# Altium schematic sheet Battery_Pack_1A.SchDoc(Battery_Pack_1A)
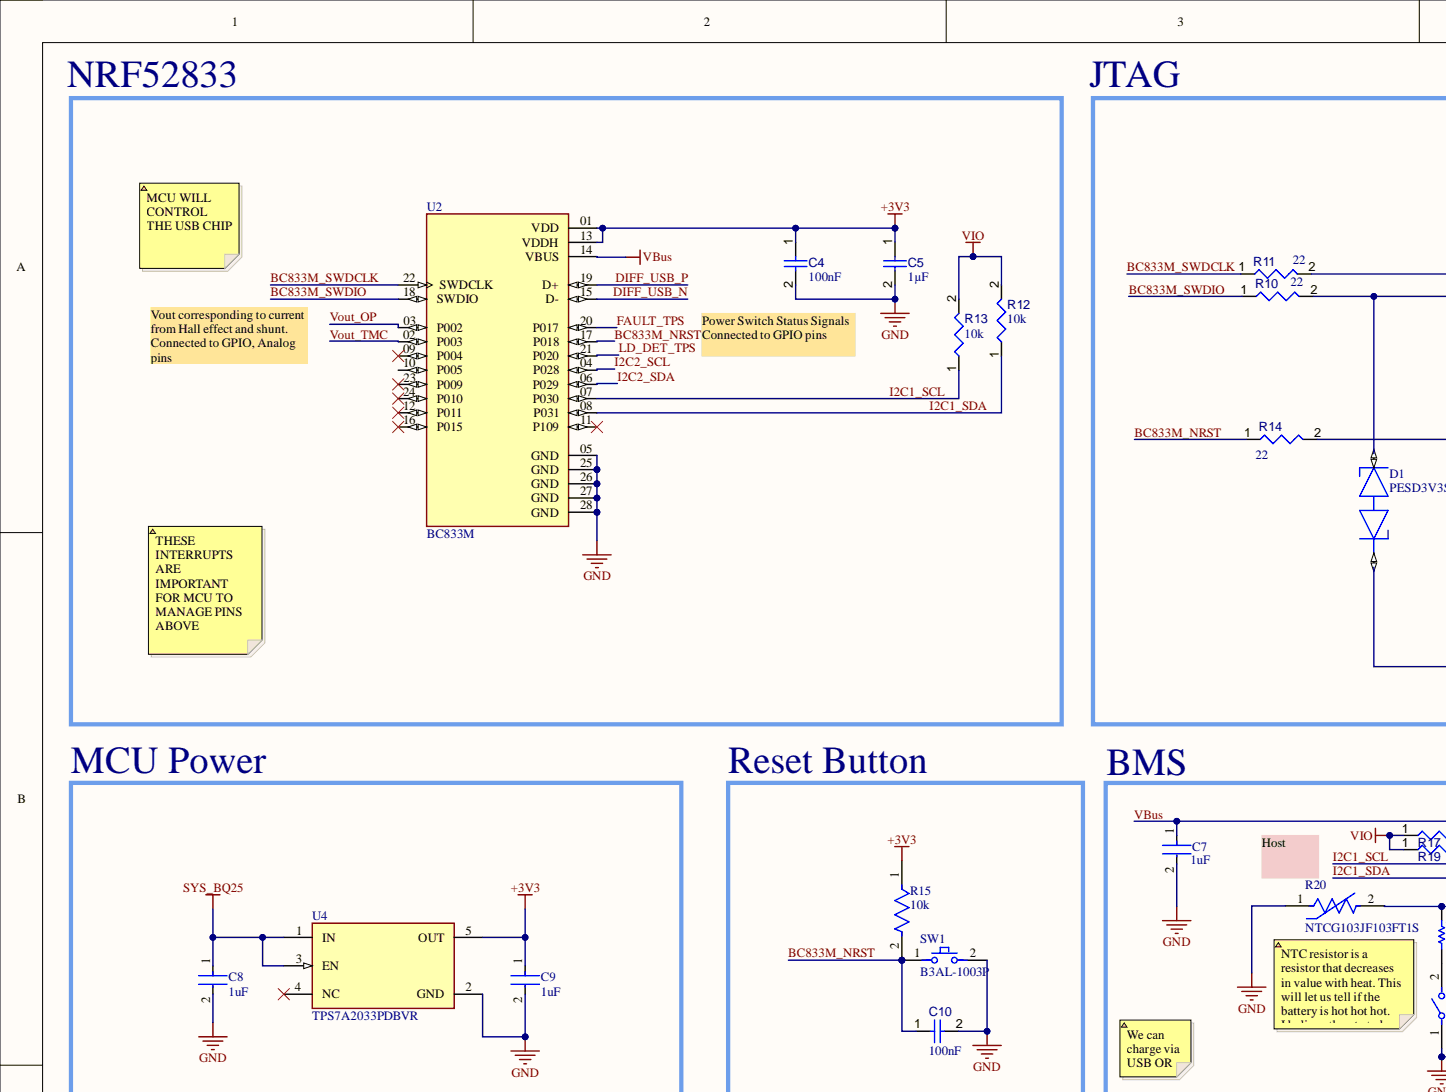
[diagram p0: Battery_Pack_1A.SchDoc, top left]
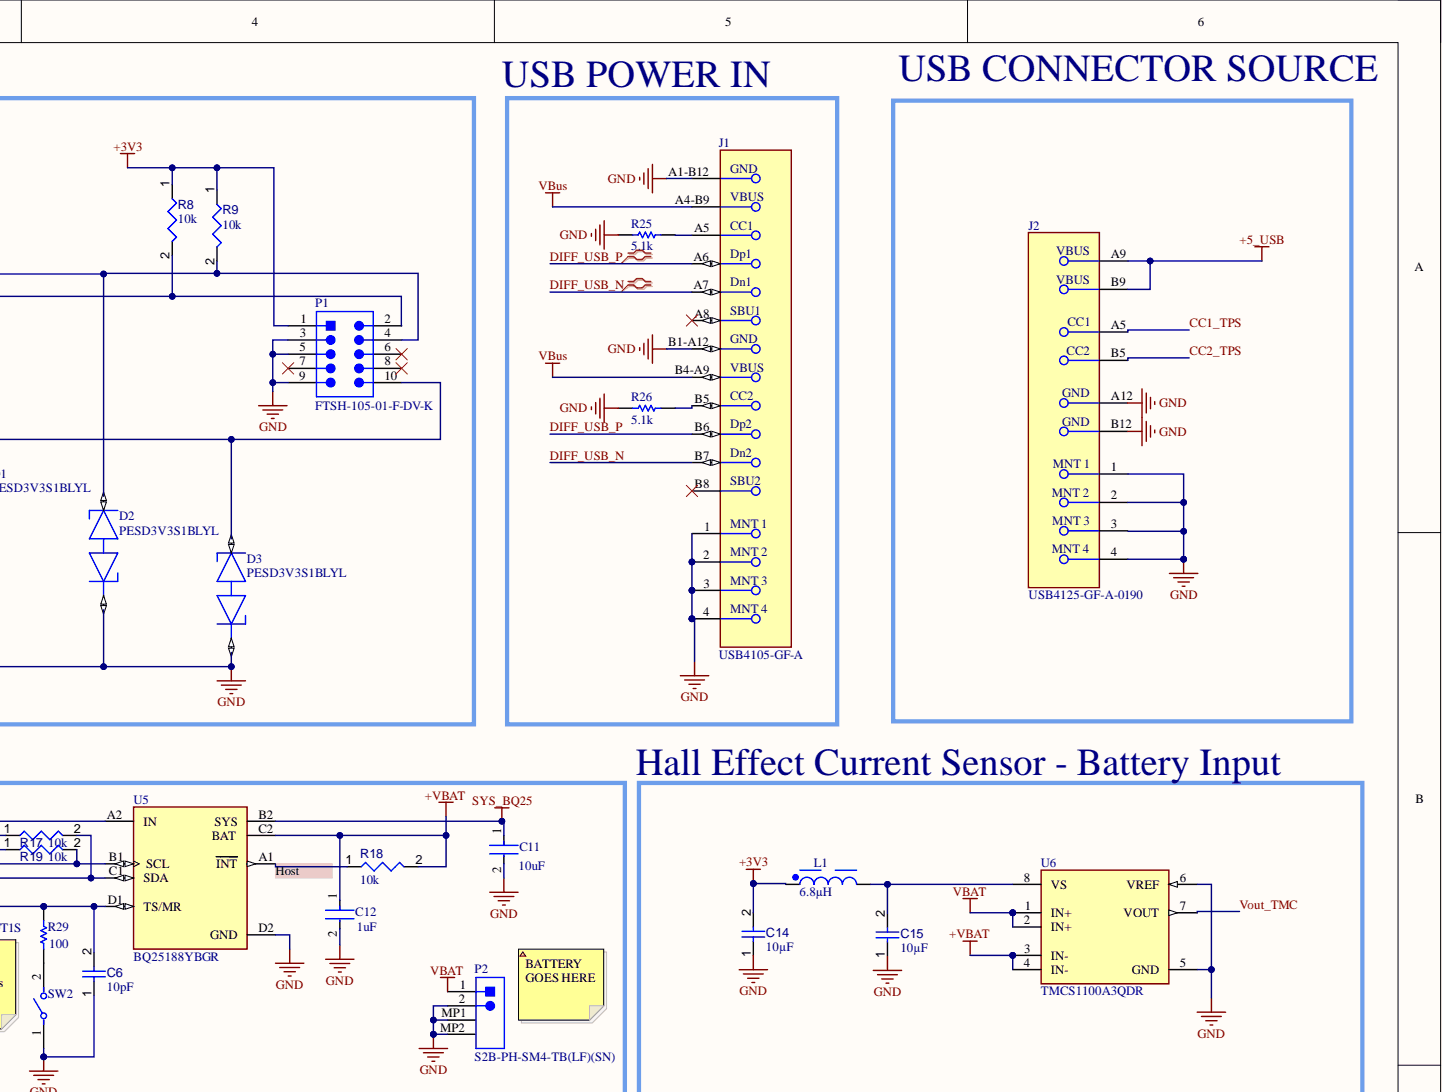
[diagram p1: Battery_Pack_1A.SchDoc, top right]
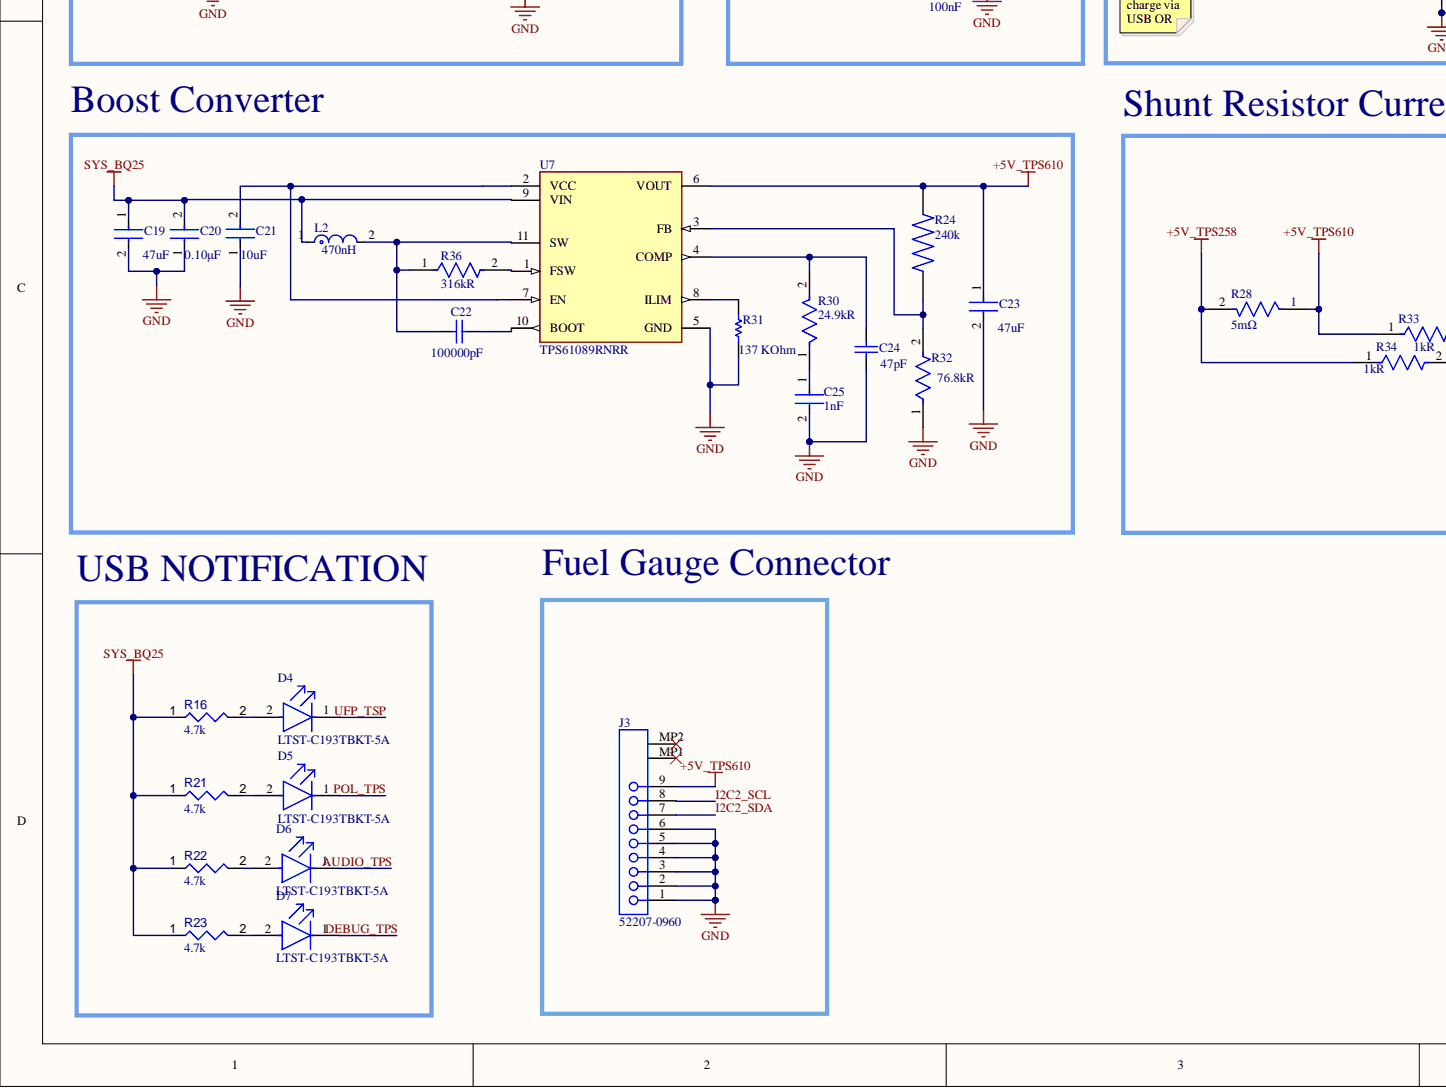
[diagram p2: Battery_Pack_1A.SchDoc, bottom left]
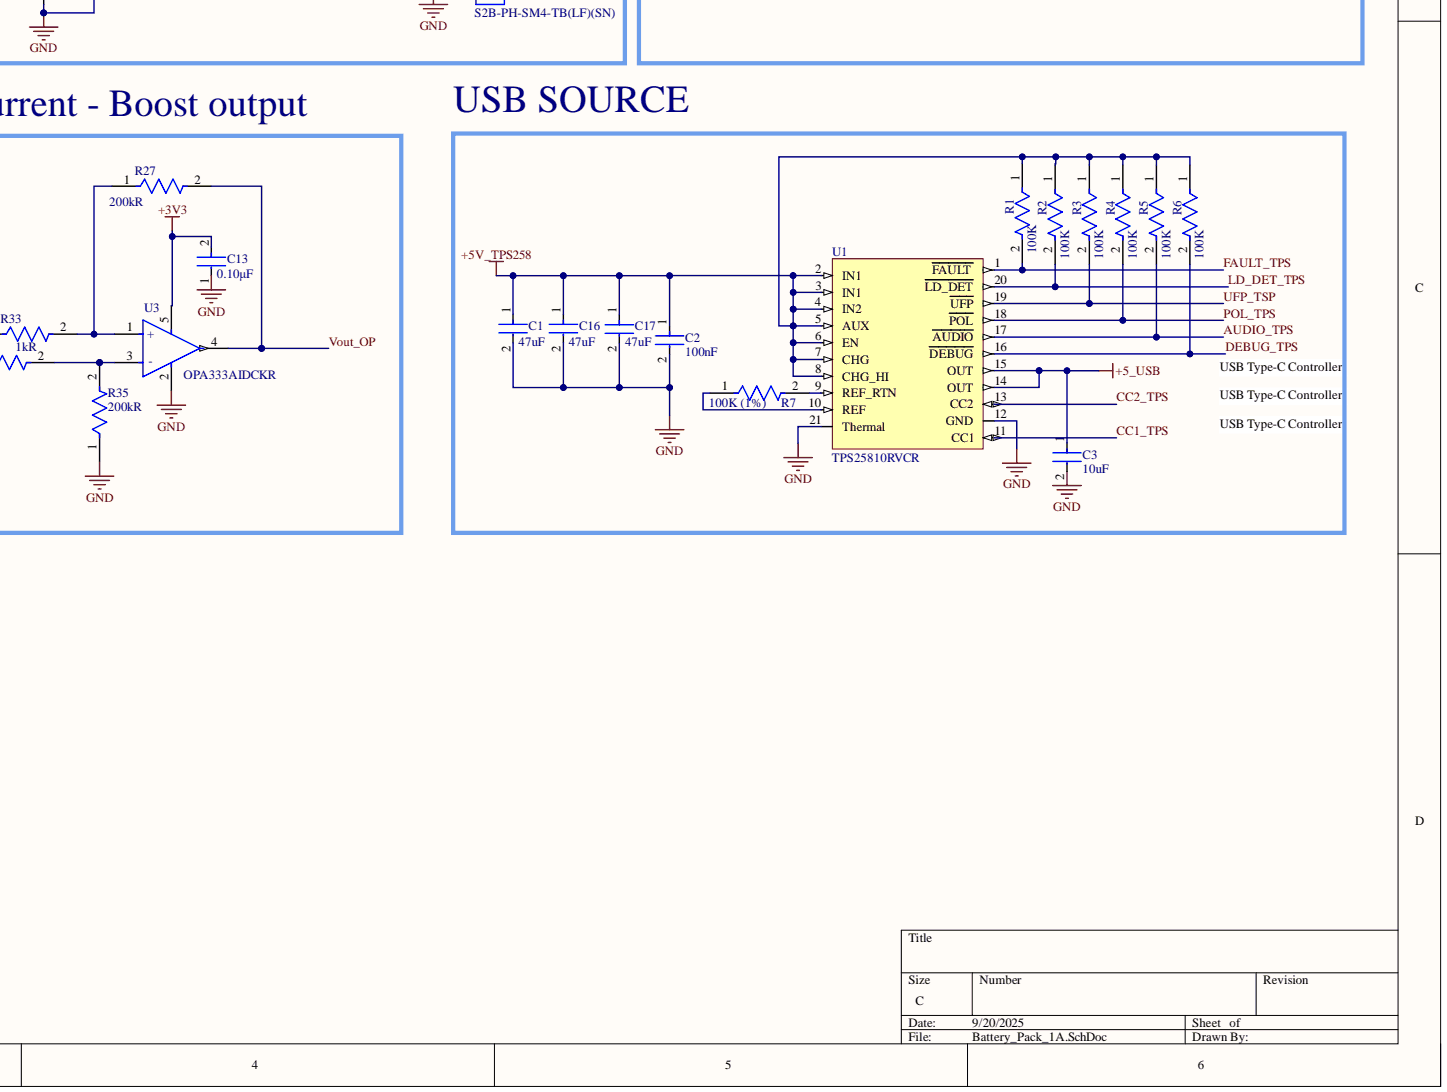
[diagram p3: Battery_Pack_1A.SchDoc, bottom right]

## Parts
C1  Capacitance: 47uF  (hidden comment: GRM21BR61A476ME15K)
C2  value 100nF  (hidden comment: CL05B104KA5NNNC)
C3  10uF
C4  value 100nF  (hidden comment: Capacitor 100nF +/-20% 25V 0402)
C5  value 1µF  (hidden comment: Capacitor 1uF +/- 10% 16V 0603)
C6  value 10pF  (hidden comment: Capacitor 10 pF +/- 5% 50 V 0402)
C7  Capacitance: 1uF  (hidden comment: EMK105BJ105KV-F)
C8  1uF
C9  1uF
C10  value 100nF  (hidden comment: Capacitor 100nF +/-20% 25V 0402)
C11  Capacitance: 10uF  (hidden comment: GRM155R61A106ME11D)
C12  Capacitance: 1uF  (hidden comment: EMK105BJ105KV-F)
C13  value 0.10μF  Capacitance: 0.10μF  (hidden comment: GRM155R71C104KA88D)
C14  value 10µF  (hidden comment: Capacitor 10µF +/-20% 25V 0805)
C15  value 10µF  (hidden comment: Capacitor 10µF +/-20% 25V 0805)
C16  Capacitance: 47uF  (hidden comment: GRM21BR61A476ME15K)
C17  Capacitance: 47uF  (hidden comment: GRM21BR61A476ME15K)
C19  Capacitance: 47uF  (hidden comment: GRM32ER61C476KE15L)
C20  value 0.10μF  Capacitance: 0.10μF  (hidden comment: GRM155R71C104KA88D)
C21  Capacitance: 10uF  (hidden comment: C1608X5R1A106M080AC)
C22  Capacitance: 100000pF  (hidden comment: GRM155R71A104KA01D)
C23  Capacitance: 47uF  (hidden comment: GRM32ER61C476KE15L)
C24  Capacitance: 47pF  (hidden comment: GRM1555C1H470GA01D)
C25  Capacitance: 1nF  (hidden comment: GRM1555C1H102JA01J)
D1  PESD3V3S1BLYL
D2  PESD3V3S1BLYL
D3  PESD3V3S1BLYL
D4  LTST-C193TBKT-5A
D5  LTST-C193TBKT-5A
D6  LTST-C193TBKT-5A
D7  LTST-C193TBKT-5A
J1  USB4105-GF-A
J2  USB4125-GF-A-0190
J3  52207-0960
L1  Inductance: 6.8µH  (hidden comment: 74439346068)
L2  Inductance: 470nH  (hidden comment: XFL4015-471MEB)
P1  FTSH-105-01-F-DV-K
P2  S2B-PH-SM4-TB(LF)(SN)
R1  100K
R2  100K
R3  100K
R4  100K
R5  100K
R6  100K
R7  100K (1%)
R8  value 10k  (hidden comment: 10KR2F)
R9  value 10k  (hidden comment: 10KR2F)
R10  value 22  (hidden comment: 22R2J)
R11  value 22  (hidden comment: 22R2J)
R12  value 10k  (hidden comment: Resistor 10k +/-1% 0402 100 mW)
R13  value 10k  (hidden comment: Resistor 10k +/-1% 0402 100 mW)
R14  value 22  (hidden comment: 22R2J)
R15  10k
R16  value 4.7k  (hidden comment: Resistor 4k7 +/-1% 0603 100 mW)
R17  value 10k  (hidden comment: Resistor 10k +/-1% 0402 100 mW)
R18  value 10k  (hidden comment: Resistor 10k +/-1% 0603 100 mW)
R19  value 10k  (hidden comment: Resistor 10k +/-1% 0402 100 mW)
R20  NTCG103JF103FT1S
R21  value 4.7k  (hidden comment: Resistor 4k7 +/-1% 0603 100 mW)
R22  value 4.7k  (hidden comment: Resistor 4k7 +/-1% 0603 100 mW)
R23  value 4.7k  (hidden comment: Resistor 4k7 +/-1% 0603 100 mW)
R24  value 240k  (hidden comment: RC0603FR-07240KL)
R25  Resistance: 5.1k  (hidden comment: RC0603FR-075K1L)
R26  Resistance: 5.1k  (hidden comment: RC0603FR-075K1L)
R27  Resistance: 200kR  (hidden comment: CRCW0603200KFKEA)
R28  value 5mΩ  Resistance: 5mΩ  (hidden comment: PU2512FKM130R005L)
R29  RC0402FR-07100RL  (value not drawn)
R30  Resistance: 24.9kR  (hidden comment: CRCW040224K9FKED)
R31  CRCW0402137KFKED  (value not drawn)
R32  Resistance: 76.8kR  (hidden comment: CRCW040276K8FKED)
R33  Resistance: 1kR  (hidden comment: AC0603FR-071KL)
R34  Resistance: 1kR  (hidden comment: AC0603FR-071KL)
R35  Resistance: 200kR  (hidden comment: CRCW0603200KFKEA)
R36  Resistance: 316kR  (hidden comment: CRCW0402316KFKED)
SW1  B3AL-1003P
SW2  MDSM-4R-12-18  (value not drawn)
U1  TPS25810RVCR
U2  BC833M
U3  OPA333AIDCKR
U4  TPS7A2033PDBVR
U5  BQ25188YBGR
U6  TMCS1100A3QDR
U7  TPS61089RNRR

## Nets
+3V3: C4.1 C5.1 C9.1 C13.2 C14.2 L1.1 P1.1 R8.1 R9.1 R15.1 U2.01(VDD) U2.13(VDDH) U3.5 U4.5(OUT)
+5_USB: C3.1 J2.A9 J2.B9 U1.14(OUT) U1.15(OUT)
+5V_TPS258: C1.1 C2.1 C16.1 C17.1 R1.1 R2.1 R3.1 R4.1 R5.1 R6.1 R28.2 R34.1 U1.2(IN1) U1.3(IN1) U1.4(IN2) U1.5(AUX) U1.6(EN) U1.7(CHG) U1.8(CHG_HI)
+5V_TPS610: C23.1 J3.9 R24.2 R28.1 R33.1 U7.6(VOUT)
+VBAT: C12.1 R18.2 U5.C2(BAT) U6.3(IN-) U6.4(IN-)
GND: C1.2 C2.2 C3.2 C4.2 C5.2 C6.1 C7.2 C8.2 C9.2 C10.2 C11.2 C12.2 C13.1 C14.1 C15.1 C16.2 C17.2 C19.2 C20.1 C21.1 C23.2 C24.1 C25.2 D1.1 D2.1 D3.1 J1.1 J1.2 J1.3 J1.4 J1.A1-B12 J1.B1-A12 J2.1 J2.2 J2.4 J2.A12 J2.B12 J3.1 J3.2 J3.3 J3.4 J3.5 J3.6 P1.3 P1.5 P1.9 P2.2 P2.MP1 P2.MP2 R20.1 R25.1 R26.1 R31.1 R32.1 R35.1 SW1.2 SW2.1 U1.12(GND) U1.21(Thermal) U2.05(GND) U2.25(GND) U2.26(GND) U2.27(GND) U2.28(GND) U3.2 U4.2(GND) U5.D2(GND) U6.5(GND) U6.6(VREF) U7.5(GND)
SYS_BQ25: C8.1 C11.1 C19.1 C20.2 L2.1 R16.1 R21.1 R22.1 R23.1 U4.1(IN) U4.3(EN) U5.B2(SYS) U7.9(VIN)
VBAT: P2.1 U6.1(IN+) U6.2(IN+)
VIO: R12.2 R13.2 R17.1 R19.1
AUDIO_TPS: D6.1 R5.2 U1.17(AUDIO)
BC833M_NRST: C10.1 R14.1 R15.2 SW1.1 U2.17(P018)
BC833M_SWDCLK: R11.1 U2.22(SWDCLK)
BC833M_SWDIO: R10.1 U2.18(SWDIO)
CC1_TPS: J2.A5 U1.11(CC1)
CC2_TPS: J2.B5 U1.13(CC2)
DEBUG_TPS: D7.1 R6.2 U1.16(DEBUG)
DIFF_USB_N: J1.A7 J1.B7 U2.15(D-)
DIFF_USB_P: J1.A6 J1.B6 U2.19(D+)
FAULT_TPS: R1.2 U1.1(FAULT) U2.20(P017)
I2C1_SCL: R13.1 R19.2 U2.07(P030) U5.B1(SCL)
I2C1_SDA: R12.1 R17.2 U2.08(P031) U5.C1(SDA)
I2C2_SCL: J3.8 U2.04(P028)
I2C2_SDA: J3.7 U2.06(P029)
LD_DET_TPS: R2.2 U1.20(LD_DET) U2.21(P020)
POL_TPS: D5.1 R4.2 U1.18(POL)
UFP_TSP: D4.1 R3.2 U1.19(UFP)
VBus: C7.1 J1.A4-B9 J1.B4-A9 U2.14(VBUS) U5.A2(IN)
Vout_OP: R27.2 U2.03(P002) U3.4
Vout_TMC: U2.02(P003) U6.7(VOUT)
NetC6_2: C6.2 R20.2 R29.2 U5.D1(TS/MR)
NetC15_2: C15.2 L1.2 U6.8(VS)
NetC21_2: C21.2 U7.2(VCC) U7.7(EN)
NetC22_1: C22.1 L2.2 R36.1 U7.11(SW)
NetC22_2: C22.2 U7.10(BOOT)
NetC24_2: C24.2 R30.2 U7.4(COMP)
NetC25_1: C25.1 R30.1
NetD1_2: D1.2 P1.2 R8.2 R10.2
NetD2_2: D2.2 P1.4 R9.2 R11.2
NetD3_2: D3.2 P1.10 R14.2
NetD4_2: D4.2 R16.2
NetD5_2: D5.2 R21.2
NetD6_2: D6.2 R22.2
NetD7_2: D7.2 R23.2
NetJ1_A5: J1.A5 R25.2
NetJ1_B5: J1.B5 R26.2
NetR7_1: R7.1 U1.10(REF)
NetR7_2: R7.2 U1.9(REF_RTN)
NetR18_1: R18.1 U5.A1(INT)
NetR24_1: R24.1 R32.2 U7.3(FB)
NetR27_1: R27.1 R33.2 U3.1(+)
NetR29_1: R29.1 SW2.2
NetR31_2: R31.2 U7.8(ILIM)
NetR34_2: R34.2 R35.2 U3.3(-)
NetR36_2: R36.2 U7.1(FSW)

Not connected: J1 A8 B8; J2 3; J3 MP1 MP2; P1 6 7 8; U2 09(P004) 10(P005) 11(P109) 12(P011) 16(P015) 23(P009) 24(P010); U4 4(NC)

## Library data of the parts
C1 C16 C17 = CMP-06035-042633-1: Chip Multilayer Ceramic Capacitors for General Purpose, 0805, 47uF, X5R, 15%, 20%, 10V; Case Code (Imperial): 0805; Case Code (Metric): 2012; Case/Package: 0805; Component Kind: Standard; Dielectric: X5R; Length: 0.079inch; Library Name: Altium Content Vault; Max Operating Temperature: 85°C; Min Operating Temperature: -55°C; Mount: Surface Mount; Package Quantity: 10000; Packaging: Tape and Reel; Termination: SMD/SMT; Thickness: 0.057inch; Tolerance: 20%; Voltage Rating: 10V; Voltage Rating (DC): 10V; Weight: 0.000335oz; Width: 0.049inch
C2 = CMP-001-00119-1: Ceramic Capacitor, Multilayer, Ceramic, 25V, 10% +Tol, 10% -Tol, X7R, 15% TC, 0.1uF, Surface Mount, 0402; Multilayer: Yes; Capacitor Type: Ceramic; Case/Package: 0402; Component Kind: Standard; Dielectric Material: Ceramic; JESD-609 Code: e3; Max Operating Temperature: 125°C; Min Operating Temperature: -55°C; Mounting Technology: Surface Mount; Package Shape: Rectangular; Packaging: Tape and Reel; Pins: 2; Rated DC Voltage (URdc): 25V; Reach SVHC Compliant: Yes; RoHS Compliant: Yes; Temperature Characteristics Code: X7R; Terminal Finish: Nickel; Termination: Wraparound; Tolerance  (fill in as +-): 10%; Tolerance (fill in as +-): 10%; Library Name: SUBC
C3 = CMP-2007-03541-2: Chip Multilayer Ceramic Capacitors for General Purpose, 0805, 10uF, X5R, 15%, 10%, 25V; Capacitance: 10uF; Case Code (Imperial): 0805; Case Code (Metric): 2012; Case/Package: 0805; Component Kind: Standard; Depth: 1.25mm; Dielectric: X5R; Height: 1.25mm; Lead Free: Lead Free; Length: 2mm; Library Name: Altium Content Vault; Material: Ceramic; Max Operating Temperature: 85°C; Min Operating Temperature: -55°C; Mount: Surface Mount; Package Quantity: 1; Packaging: Tape and Reel; Radiation Hardening: No; Termination: SMD/SMT; Thickness: 0.053inch; Tolerance: 10%; Voltage: 25V; Voltage Rating: 25V; Voltage Rating (DC): 25V; Width: 0.049inch
C4 C10 = CMP-001-00065-4: Chip Capacitor, 100nF +/-20%, 25V, 0402, Thickness 0.6 mm; Tolerance: 10%; Case/Package: 0402; Component Kind: Standard; Max Operating Temperature: 85°C; Min Operating Temperature: -55°C; Mounting Technology: SMT; Pins: 2; Voltage Rating: 25V; Library Name: SUBC
C5 = CMP-001-00004-6: Chip Capacitor, 1 uF, +/- 10%, 16 V, 0603 (1608 Metric); Capacitor Type: Ceramic; Case/Package: 0603; Component Kind: Standard; Max Operating Temperature: 125°C; Min Operating Temperature: -55°C; Mounting Technology: SM; Pins: 2; RoHS Compliant: True; Tolerance: 10%; Voltage Rating: 16V; Library Name: SUBC
C6 = CMP-001-00013-6: Chip Capacitor, 10 pF, +/- 5%, 50 V, 0402 (1005 Metric); Capacitor Type: Ceramic; Voltage Rating: 50V; Case/Package: 0402; Component Kind: Standard; Max Operating Temperature: 125°C; Min Operating Temperature: -55°C; Mounting Technology: SM; Pins: 2; RoHS Compliant: True; Tolerance: 5%; Library Name: SUBC
C7 C12 = CMP-14477-000104-2: None; Dielectric: X5R; Tolerance: 10%; Voltage Rating: 16V; Case Code (Imperial): 0402; Case Code (Metric): 1005; Case/Package: 0402; Component Kind: Standard; Construction: Flat; Depth: 0.5mm; Halogen Free: Halogen Free; Height: 0.5mm; Length: 1mm; Library Name: Altium Content Vault; Max Operating Temperature: 85°C; Min Operating Temperature: -55°C; Mount: Surface Mount; Number of Pins: 2; Package Quantity: 1; Packaging: Tape and Reel; Radiation Hardening: No; RoHS Compliant: Yes; Series: M; Termination: SMD/SMT; Thickness: 0.022inch; Voltage Rating (DC): 16V; Width: 0.02inch
C8 C9 = CMP-2000-05016-2: General Purpose Ceramic Capacitor, 0805, 100nF, 10%, X7R, 0.15, 50V; Dissipation Factor: 2.5%; Insulation Resistance: 100GR; Capacitance: 100nF; Case Code (Imperial): 0805; Case Code (Metric): 2012; Case/Package: 0805; Component Kind: Standard; Depth: 1.25mm; Dielectric: X7R; Dielectric Material: Ceramic; Height: 0.5mm; Lead Free: Lead Free; Length: 2mm; Library Name: Altium Content Vault; Max Operating Temperature: 125°C; Min Operating Temperature: -55°C; Mount: Surface Mount; Number of Pins: 2; Package Quantity: 4000; Packaging: Cut Tape; Radiation Hardening: No; REACH SVHC: No SVHC; RoHS Compliant: Yes; Series: MLCC X7R; Termination: Standard; Thickness: 0.037inch; Tolerance: 10%; Voltage: 50V; Voltage Rating: 50V; Voltage Rating (DC): 50V; Weight: 0.001411oz; Width: 1.2mm
C11 = CMP-06035-026070-1: Chip Multilayer Ceramic Capacitors for General Purpose, 0402, 10uF, X5R, 15%, 20%, 10V; Component Kind: Standard; Library Name: Altium Content Vault
C13 C20 = CMP-2100-03641-2: CAP CER 0.1UF 16V X7R 0402; Manufacturer: Murata; Material: Ceramic; Standoff Height: 0mm; Tolerance: 10%; Voltage Rating: 16Vdc; Category: Cap; Component Kind: Standard; ComponentLink2Description: Datasheet; ComponentLink2URL: https://search.murata.co.jp/Ceramy/image/img/A01X/G101/ENG/GRM155R71H471KA01-01A.pdf; Device Class L1: Passive Components; Device Class L2: Capacitors; Device Class L3: Ceramic Capacitors; Digikey Description: CAP CER 0.1UF 16V X7R 0402; Height: 0.55mm; Ipc Land Pattern Name: CAPC10050X219; Lead Free: yes; Library Name: Altium Content Vault; Manufacturer Part Number: GRM155R71C104KA88D; Mouser Description: 0402 0.1 uF 16V ±10 % Tolerance X7R SMT Multilayer Ceramic Capacitor; Octopart: https://octopart.com/grm155r71c104ka88d-murata-196880; Package: GRM15; RoHS: TRUE; Temperature: -55°C to +125°C; Temperature Characteristic: X7R; Temperature Range High: +125°C; Temperature Range Low: -55°C
C14 C15 = CMP-001-00079-4: Chip Capacitor, 10µF +/-20%, 25V, 0805, Thickness 1.45 mm; Tolerance: 10%; Case/Package: 0805; Component Kind: Standard; Max Operating Temperature: 85°C; Min Operating Temperature: -55°C; Mounting Technology: SMT; Pins: 2; Voltage Rating: 25V; Library Name: SUBC
C19 C23 = CMP-2000-06362-2: CAP CER 47UF 16V X5R 1210; Material: Ceramic; Tolerance: 10%; Case Code (Imperial): 1210; Case Code (Metric): 3225; Case/Package: 1210; Component Kind: Standard; Depth: 2.5mm; Dielectric: X5R; Height: 2.5mm; Lead Free: Lead Free; Length: 3.2mm; Library Name: Altium Content Vault; Max Operating Temperature: 85°C; Min Operating Temperature: -55°C; Mount: Surface Mount; Number of Pins: 2; Package Quantity: 1; Packaging: Cut Tape; Radiation Hardening: No; REACH SVHC: No SVHC; RoHS Compliant: Yes; Termination: SMD/SMT; Thickness: 0.106inch; Voltage: 16V; Voltage Rating: 16V; Voltage Rating (DC): 16V; Width: 0.098inch
C21 = CMP-2000-04980-2: Multilayer Ceramic Capacitors 10μF ±20% 10V X5R SMD 0603; Case Code (Imperial): 0603; Case Code (Metric): 1608; Case/Package: 0603; Component Kind: Standard; Construction: Flat; Depth: 0.8mm; Dielectric: X5R; Dielectric Material: Ceramic; Height: 0.8mm; Lead Free: Lead Free; Length: 1.6mm; Library Name: Altium Content Vault; Max Operating Temperature: 85°C; Min Operating Temperature: -55°C; Mount: Surface Mount; Number of Pins: 2; Package Quantity: 1; Packaging: Tape and Reel; Radiation Hardening: No; REACH SVHC: No SVHC; Resistor Type: Ceramic; RoHS Compliant: Yes; Series: C; Termination: SMD/SMT; Thickness: 0.035inch; Tolerance: 20%; Voltage Rating: 10V; Voltage Rating (DC): 10V; Weight: 7.1E-05oz; Width: 0.031inch
C22 = CMP-2000-06331-1: Chip Capacitor, 0.1 uF, +/- 10%, 10 V, -55 to 125 degC, 0402 (1005 Metric), RoHS, Tape and Reel; Manufacturer: Murata; Dielectric: X7R; Rated Voltage: 10V; Case Size/Dimension: 0402; Component Kind: Standard; Datasheet URL: http://search.murata.co.jp/Ceramy/image/img/A01X/G101/ENG/GRM155R71A104KA01-01.pdf; Datasheet Version: 02/2016; Library Name: Altium Content Vault; Manufacturer URL: http://www.murata.com/; Mounting Technology: SurfaceMount; Package Description: 2-Pin Surface Mount Device, Body 1 x 0.5 mm; Package Reference: 0402; Tolerance (%): ±10%
C24 = CMP-2008-04366-1: GRM1555C1H470GA01D  CAP CER 47PF 50V C0G/NP0 0402; Manufacturer: Murata; Component Kind: Standard; Library Name: Altium Content Vault; Part Number: GRM1555C1H470GA01D
C25 = CMP-2008-04255-2: Chip Multilayer Ceramic Capacitors for General Purpose, 0402, 1000pF, C0G, 30ppm/°C, 5%, 50V; Case Code (Imperial): 0402; Case Code (Metric): 1005; Case/Package: 0402; Component Kind: Standard; Depth: 0.5mm; Dielectric: C0G; Height: 0.5mm; Lead Free: Lead Free; Length: 1mm; Library Name: Altium Content Vault; Max Operating Temperature: 125°C; Min Operating Temperature: -55°C; Mount: Surface Mount; Package Quantity: 50000; Packaging: Tape and Reel; Termination: SMD/SMT; Thickness: 0.022inch; Tolerance: 5%; Voltage: 50V; Voltage Rating: 50V; Voltage Rating (DC): 50V; Width: 0.02inch
D1-D3 = CMP-004-00035-1: 6.5V (Typ) Clamp 15A (8/20µs) Ipp Tvs Diode Surface Mount DFN1006-2; MF: Nexperia; Case/Package: DFN1006-2-2 Nexperia USA Inc.; Check_prices: https://www.snapeda.com/parts/PESD3V3S1BLYL/Nexperia/view-part/?ref=eda; Component Kind: Standard; Component Type: Standard; Design Item ID: PESD3V3S1BLYL; MP: PESD3V3S1BLYL; Pins: 2; SnapEDA_Link: https://www.snapeda.com/parts/PESD3V3S1BLYL/Nexperia/view-part/?ref=snap; Source: PESD3V3S1BLYL.SchLib; Library Name: SUBC
D4-D7 = CMP-007-00044-1: Single Color LED, Blue, Water Clear, 1.15mm; Channels: 1; Forward Current: 20mA; Luminous Intensity-Nom: 15mcd; Overall Height: 0.35mm; Shape: Rectangular; Viewing Angle: 130°; Color: Blue; Component Kind: Standard; JESD-609 Code: e3; Lens Style: WATER CLEAR; Max Operating Temperature: 80°C; Min Operating Temperature: -20°C; Mounting Technology: Surface Mount; Optoelectronic Device Type: SINGLE COLOR LED; Packaging: Tape and Reel; Pins: 2; Reach SVHC Compliant: Yes; RoHS Compliant: Yes; Size: 1.15mm; Terminal Finish: Tin; Terminal Pitch: 1.5mm; Library Name: SUBC
J1 = CMP-002-00130-1: Conn USB 2.0 Type C RCP 24 POS 0.3mm/0.5mm Solder RA SMD 24 Terminal 1 Port T/R; Component Kind: Standard; Library Name: SUBC
J2 = CMP-245163-000004-1: CONN RCPT TYPE C 6P SMD RA; Component Kind: Standard; Library Name: Altium Content Vault
J3 = CMP-1241-00181-1: 1.0 mm Pitch Easy-On(TM) Type FPC Connector, 2.7 mm Mated Height, Right Angle, ZIF, SMT, Top Contact, 9 Circuits, -30 to 85 degC, ELV and RoHS Compliant, Tape and Reel; Manufacturer: Molex; Circuits (Loaded): 9; Current (Max per Contact): 1A; Durability (mating cycles max): 30; Entry Angle: 90degrees Angle; Mated Height: 2.70mm; Material - Metal: Phosphor Bronze; Material - Plating Mating: Gold; Material - Plating Termination: Tin; PCB Locator: No; PCB Retention: Yes; Pitch - Mating Interface: 1.00mm; Voltage (Max): 125V; Component Kind: Standard; ComponentLink1Description: Manufacturer URL; ComponentLink1URL: http://www.molex.com/molex/index.jsp; ComponentLink2Description: Datasheet; ComponentLink2URL: http://www.molex.com/webdocs/datasheets/pdf/en-us/0522070960_FFC_FPC_CONNECTORS.pdf; ComponentLink3Description: Package Specification; ComponentLink3URL: http://www.molex.com/pdm_docs/sd/522070960_sd.pdf; Contact Position: Top; Library Name: Altium Content Vault; Mounting Technology: Surface Mount; Number of Rows: 1; Orientation: Right Angle; PackageDescription: 9-Lead FPC Connector, Pitch 1.0 mm; PackageReference: 52207-0960; PackageVersion: Rev. F, 03/2007; Packing: Tape and Reel; PartNumber: 52207-0960; Pitch - Termination Interface: 1.00mm; Polarized to PCB: Yes; RoHS: TRUE; Stackable: No
L1 = CMP-03913-75811202-1: 74439346068 Fixed Ind 6.8UH 6.5A 17.6MOHM Sm; DC Resistance (DCR): 17.6mΩ; Inductance Tolerance: 20%; Saturation Current: 5.65A; Ambient Temperature Range High: 85°C; Ambient Temperature Range Low: -40°C; Component Kind: Standard; Current Rating: 6.5A; Height: 6.1mm; Length: 6.65mm; Library Name: Altium Content Vault; Max Operating Temperature: 125°C; Min Operating Temperature: -40°C; Mount: Surface Mount; NexarPartId: 75811202; Number of Pins: 2; REACH SVHC: No SVHC; RMS Current (Irms): 6.5A; RoHS: Compliant; Width: 6.45mm
L2 = CMP-11268-000114-1: Surface Mount Power Inductor, XFL4015 Series, 470 nH, 20%, Shielded, 8360 ohm, 11.2 A; Core Material: Composite; DC Resistance (DCR): 8.36mR; Max DC Current: 11.2A; Saturation Current: 3.5A; Self Resonant Frequency: 89MHz; Test Frequency: 100kHz; Case/Package: SMD/SMT; Component Kind: Standard; Height: 1.6mm; Inductance Tolerance: 20%; Lead Free: Contains Lead; Length: 4.3mm; Library Name: Altium Content Vault; Max Operating Temperature: 125°C; Min Operating Temperature: -40°C; Mount: Surface Mount; Package Quantity: 40; Packaging: Tape and Reel; REACH SVHC: No SVHC; RMS Current (Irms): 11.2A; RoHS Compliant: Yes; Series: XFL4015; Shielding: Shielded; Termination: SMD/SMT; Tolerance: 20%; Width: 4.3mm
P1 = CMP-002-00122-1: Board Connector, 10 Contact(s), 2 Row(s), Male, Straight, 0.05 inch Pitch, Surface Mount Terminal, Locking, Black Insulator; Additional Feature: ROHS COMPLIANT; Body Breadth: 0.135inch; Body Length: 0.25inch; Body/Shell Style: RECEPTACLE; Contact Pattern: RECTANGULAR; Contact Style: SQ PIN-SKT; DIN Conformance: No; Mating Information: MULTIPLE MATING PARTS AVAILABLE; Number Of Connectors: 1; Number of Contacts: 10; Number Of PCB Rows: 2; Option: General Purpose; PCB Contact Row Spacing: 4.064mm; Plating Thickness: 3uin; Reference Standard: UL; Reliability: COMMERCIAL; Component Kind: Standard; Connector Type: BOARD CONNECTOR; Contact Finish - Mating: GOLD FLASH OVER NICKEL (50); Contact Finish - Termination: Tin (Sn) - with Nickel (Ni) barrier; Contact Gender: Male; Contact Material: Bronze; Filter Feature: No; IEC Conformance: No; Insulation Material: Polymer; JESD-609 Code: e3; Mating Contact Pitch: 0.05inch; Mating Contact Row Spacing: 0.05inch; MIL Conformance: No; Mixed Contacts: No; Mounting Option 1: LOCKING; Mounting Style: STRAIGHT; Mounting Technology: Board; Number of Rows Loaded: 2; PCB Contact Pattern: RECTANGULAR; Reach SVHC Compliant: Yes; RoHS Compliant: Yes; Terminal Pitch: 1.27mm; Termination: SMD/SMT; Library Name: SUBC
P2 = CMP-002-00031-2: Male Header, Pitch 2 mm, 1 x 2 Position, Height 5.5 mm, -25 to 85 degC, RoHS, Tape and Reel; Manufacturer: JST; Case/Package: S2B-PH-SM4-TB(LF)(SN)-2; Component Kind: Standard; Connector Type: Header; Datasheet URL: http://www.jst-mfg.com/product/pdf/eng/ePH.pdf; Datasheet Version: Dec-12; Gender: Male; Manufacturer URL: http://www.jst.com/home2.html; Max Operating Temperature: 85°C; Min Operating Temperature: -25°C; Mounting Technology: SM; Package Description: Male Header, Pitch 2 mm, 1 x 2 Position, Height 5.5 mm; Package Reference: S2B-PH-SM4-TB(LF)(SN)-2; RoHS Compliant: Unknown; Library Name: SUBC
R1-R7 = CMP-2100-03662-2: RES SMD 100K OHM 1% 1/10W 0603; Max Power Dissipation: 100mW; Resistance: 100kR; Temperature Coefficient: 100ppm/°C; Voltage Rating: 50V; Working Voltage: 75V; Case Code (Imperial): 0603; Case Code (Metric): 1608; Case/Package: 0603; Component Kind: Standard; Construction: Rectangular; Depth: 0.8mm; Features: Moisture Resistant; Height: 0.45mm; Lead Free: Lead Free; Length: 1.6mm; Library Name: Altium Content Vault; Max Operating Temperature: 155°C; Military Standard: Not; Min Operating Temperature: -55°C; Number of Pins: 2; Number of Terminations: 2; Packaging: Tape and Reel; Power Rating: 100mW; REACH SVHC: No SVHC; Resistance Tolerance: 1%; Resistor Type: Thick Film; RoHS Compliant: Yes; Series: RC; Technology: THICK FILM; Termination: SMD/SMT; Tolerance: 1%; Voltage Rating (DC): 50V; Width: 0.8mm
R8 R9 = CMP-009-00066-2: 10K 0.063W 1% 0402 (1005 Metric)  SMD; Power: 63mW; Case/Package: 0402; Component Kind: Standard; Mounting Technology: SMT; Pins: 2; Tolerance: 1%; Library Name: SUBC
R10 R11 R14 = CMP-009-00082-2: 22R 0.063W 5% 0402 (1005 Metric)  SMD; Power: 63mW; Case/Package: 0402; Component Kind: Standard; Mounting Technology: SMT; Pins: 2; Tolerance: 5%; Library Name: SUBC
R12 R13 R17 R19 = CMP-009-00015-5: Chip Resistor, 10 KOhm, +/- 1%, 0.1 W, -55 to 155 degC, 0402 (1005 Metric); Power: 100mW; Voltage Rating: 50V; Case/Package: 0402; Component Kind: Standard; Max Operating Temperature: 155°C; Min Operating Temperature: -55°C; Mounting Technology: SM; Pins: 2; RoHS Compliant: True; Tolerance: 1%; Library Name: SUBC
R15 = CMP-2100-03664-2: RES 10K OHM 1% 1/10W 0603; Max Power Dissipation: 100mW; Resistance: 10kR; Temperature Coefficient: 100ppm/°C; Voltage Rating: 75V; Voltage Rating (DC): 50V; Case Code (Imperial): 0603; Case Code (Metric): 1608; Case/Package: 0603; Component Kind: Standard; Construction: Rectangular; Depth: 0.8mm; Features: Moisture Resistant; Height: 0.45mm; Lead Free: Lead Free; Lead Pitch: 0.3mm; Length: 1.6mm; Library Name: Altium Content Vault; Max Operating Temperature: 155°C; Military Standard: Not; Min Operating Temperature: -55°C; Number of Pins: 2; Number of Terminations: 2; Packaging: Tape and Reel; Power Rating: 100mW; Resistance Tolerance: 1%; Resistor Type: Thick Film; RoHS Compliant: Yes; Series: RC; Size Code: 0603; Termination: SMD/SMT; Tolerance: 1%; Weight: 7.1E-05oz; Width: 0.8mm
R16 R21-R23 = CMP-009-00031-5: Chip Resistor, 4.7 KOhm, +/- 1%, 0.1 W, -55 to 155 degC, 0603 (1608 Metric); Power: 100mW; Case/Package: 0603; Component Kind: Standard; Max Operating Temperature: 155°C; Min Operating Temperature: -55°C; Mounting Technology: SM; Pins: 2; RoHS Compliant: True; Tolerance: 1%; Library Name: SUBC
R18 = CMP-009-00017-5: Chip Resistor, 10 KOhm, +/- 1%, 01 W, -55 to 155 degC, 0603 (1608 Metric); Power: 100mW; Voltage Rating: 50V; Case/Package: 0603; Component Kind: Standard; Max Operating Temperature: 155°C; Min Operating Temperature: -55°C; Mounting Technology: SM; Pins: 2; RoHS Compliant: True; Tolerance: 1%; Library Name: SUBC
R20 = CMP-08233-000003-1: THERMISTOR NTC 10KOHM 3380K 0402; Tolerance: 1%; Case Code (Imperial): 0402; Case Code (Metric): 1005; Case/Package: 0402; Component Kind: Standard; Library Name: Altium Content Vault; Max Operating Temperature: 125°C; Min Operating Temperature: -40°C; Mount: Surface Mount; Number of Pins: 2; Packaging: Tape and Reel
R24 = CMP-009-00177-1: 240 kOhms Â±1% 0.1W, 1/10W Chip Resistor 0603 (1608 Metric) Moisture Resistant Thick Film; MF: Yageo; Case/Package: 1608 Yageo; Check_prices: https://www.snapeda.com/parts/RC0603FR-07240KL/Yageo/view-part/?ref=eda; Component Kind: Standard; Component Type: Standard; Design Item ID: RC0603FR-07240KL; MP: RC0603FR-07240KL; Pins: 2; SnapEDA_Link: https://www.snapeda.com/parts/RC0603FR-07240KL/Yageo/view-part/?ref=snap; Source: RC0603FR-07240KL.SchLib; Library Name: Rosemary Chan
R25 R26 = CMP-2000-04091-1: Manufacturer: Yageo; Component Kind: Standard; Library Name: Altium Content Vault; Part Number: RC0603FR-075K1L
R27 R35 = CMP-2000-03059-2: RES Thick Film, 200kΩ, 1%, 0.1W, 100ppm/°C, 0603; Max Power Dissipation: 100mW; Voltage Rating: 75V; Case Code (Imperial): 0603; Case Code (Metric): 1608; Case/Package: 0603; Component Kind: Standard; Composition: Thick Film; Contact Plating: Tin; Depth: 0.85mm; Features: Automotive AEC-Q200; Height: 0.45mm; Lead Free: Lead Free; Length: 1.55mm; Library Name: Altium Content Vault; Max Operating Temperature: 155°C; Military Standard: Not; Min Operating Temperature: -55°C; Mount: Surface Mount; Number of Terminations: 2; Package Quantity: 10; Packaging: Tape and Reel; Power Rating: 100mW; Radiation Hardening: No; Temperature Coefficient: 100ppm/°C; Termination: SMD/SMT; Tolerance: 1%; Voltage Rating (DC): 75V; Weight: 7.1E-05oz; Width: 0.033inch; Working Voltage: 75V
R28 = CMP-03412-006804-1: METAL SHUNT RESISTOR 2512 1% 75P; Manufacturer: Yageo; Automotive Grade: Grade 0; Power Rating: 4W; Temperature Coefficient: 75ppm/°C; Automotive: Yes; Category: Res; Component Kind: Standard; ComponentLink2Description: Datasheet; ComponentLink2URL: http://www.yageo.com/documents/recent/PYu-PU_51_RoHS_L_6.pdf; Composition: Metal Element; Device Class L1: Passive Components; Device Class L2: Resistors; Device Class L3: Chip SMD Resistors; Digikey Description: METAL SHUNT RESISTOR 2512 1% 75P; Height: 0.94mm; Ipc Land Pattern Name: RESC635318X66; Lead Free: Yes; Library Name: Altium Content Vault; Manufacturer Part Number: PU2512FKM130R005L; Octopart: https://octopart.com/pu2512fkm130r005l-yageo-96774569; Package: PU2512-0R005; RoHS: TRUE; Series: PU; Temperature: -65°C to +170°C; Temperature Range High: +170°C; Temperature Range Low: -65°C; Tolerance: 1%
R29 = CMP-2002-07344-1: Manufacturer: Yageo / Phycomp; Resistance: 100; Component Kind: Standard; Library Name: Altium Content Vault; Part Number: RC0402FR-07100RL
R30 = CMP-2002-08407-2: RES Thick Film, 24.9kΩ, 1%, 0.063W, 100ppm/°C, 0402; Max Power Dissipation: 63mW; Voltage Rating: 50V; Case Code (Imperial): 0402; Case Code (Metric): 1005; Case/Package: 0402; Component Kind: Standard; Composition: Thick Film; Contact Plating: Tin; Depth: 0.5mm; Features: Automotive AEC-Q200; Height: 0.35mm; Lead Free: Lead Free; Length: 1mm; Library Name: Altium Content Vault; Max Operating Temperature: 155°C; Military Standard: Not; Min Operating Temperature: -55°C; Mount: Surface Mount; Number of Pins: 2; Number of Terminations: 2; Package Quantity: 10; Packaging: Tape and Reel; Power Rating: 63mW; Radiation Hardening: No; Temperature Coefficient: 100ppm/°C; Termination: SMD/SMT; Tolerance: 1%; Voltage Rating (DC): 50V; Weight: 2.3E-05oz; Width: 0.5mm
R31 = CMP-1011-00750-4: Chip Resistor, 137 KOhm, +/- 1%, 63 mW, -55 to 155 degC, 0402 (1005 Metric), RoHS, Tape and Reel; Manufacturer: Basic Passives; Case\/Package: 0402; Power Rating: 63 mW; Voltage Rating: 50 V; Case Code (Imperial): 0402; Case Code (Metric): 1005; Component Kind: Standard; ComponentLink1Description: Manufacturer URL; ComponentLink1URL: http://www.vishay.com/; ComponentLink2Description: Datasheet; ComponentLink2URL: http://www.vishay.com/docs/20035/dcrcwe3.pdf; DatasheetVersion: 06/2012; Height: 0.35 mm; Length: 1 mm; Library Name: Altium Content Vault; Max Operating Temperature: 155 degC; Min Operating Temperature: -55 degC; Mount: Surface Mount; Mounting Technology: Surface Mount; Package Quantity: 10000; PackageDescription: 2-Pin Surface Mount Device, Body 1 x 0.5 mm; PackageReference: 0402; Packaging: Tape and Reel; PartNumber: CRCW0402137KFKED; Pins: 2; Radiation Hardening: No; REACH SVHC: Unknown; Resistance: 137 KOhm; RoHSCompliant: true; Tolerance: 1%; Weight: 2.3E-05oz; Width: 0.5 mm
R32 = CMP-2002-00214-2: RES Thick Film, 76.8kΩ, 1%, 0.063W, 100ppm/°C, 0402; Max Power Dissipation: 63mW; Voltage Rating: 50V; Case Code (Imperial): 0402; Case Code (Metric): 1005; Case/Package: 0402; Component Kind: Standard; Composition: Thick Film; Contact Plating: Tin; Depth: 0.5mm; Features: Automotive AEC-Q200; Height: 0.016inch; Lead Free: Lead Free; Length: 1mm; Library Name: Altium Content Vault; Max Operating Temperature: 155°C; Military Standard: Not; Min Operating Temperature: -55°C; Number of Terminations: 2; Package Quantity: 10; Packaging: Tape and Reel; Power Rating: 63mW; Radiation Hardening: No; Temperature Coefficient: 100ppm/°C; Termination: SMD/SMT; Tolerance: 1%; Voltage Rating (DC): 50V; Weight: 2.3E-05oz; Width: 0.5mm
R33 R34 = CMP-2000-04828-3: RES 1kΩ 1.00% 0.1W 0603; Max Power Dissipation: 100mW; Temperature Coefficient: 100ppm/°C; Tolerance: 1%; Voltage Rating: 50V; Case Code (Imperial): 0603; Case Code (Metric): 1608; Case/Package: 0603; Component Kind: Standard; Construction: Rectangular; Depth: 0.8mm; Features: Moisture Resistant; Height: 0.022inch; Lead Free: Lead Free; Length: 0.063inch; Library Name: Altium Content Vault; Max Operating Temperature: 155°C; Military Standard: Not; Min Operating Temperature: -55°C; Number of Terminations: 2; Package Quantity: 1; Packaging: Tape and Reel; Power Rating: 100mW; Ratings: AEC-Q200; Resistor Type: Thick Film; RoHS Compliant: Yes; Series: AC; Size Code: 0603; Termination: SMD/SMT; Width: 0.031inch
R36 = CMP-2002-08513-2: RES Thick Film, 316kΩ, 1%, 0.063W, 100ppm/°C, 0402; Max Power Dissipation: 63mW; Voltage Rating: 50V; Case Code (Imperial): 0402; Case Code (Metric): 1005; Case/Package: 0402; Component Kind: Standard; Composition: Thick Film; Contact Plating: Tin; Depth: 0.5mm; Features: Automotive AEC-Q200; Height: 0.35mm; Lead Free: Lead Free; Length: 1mm; Library Name: Altium Content Vault; Max Operating Temperature: 155°C; Military Standard: Not; Min Operating Temperature: -55°C; Mount: Surface Mount; Number of Pins: 2; Number of Terminations: 2; Package Quantity: 10000; Packaging: Tape and Reel; Power Rating: 63mW; Radiation Hardening: No; Temperature Coefficient: 100ppm/°C; Termination: SMD/SMT; Tolerance: 1%; Voltage Rating (DC): 50V; Weight: 2.3E-05oz; Width: 0.5mm
SW1 = CMP-00028-00009-1: Switch Tactile N.O. SPST Button J-Bend 0.05A 16VDC 3.5N SMD T/R; Actuator Color: White; Contact Current Rating: 50mA; Contact Resistance: 100mR; Electrical Life: 1000000Cycles; Illumination Type: Non-Illuminated,Illuminated; Max Voltage Rating (DC): 16V; Switch Function: Off-Mom; Switch Travel: 1.3mm; Component Kind: Standard; Contact Plating: Silver; Current Rating: 50mA; Max Operating Temperature: 90°C; Mechanical Life: 1000000Cycles; Min Operating Temperature: -40°C; Mounting Technology: Surface Mount; Operating Force: 3.5N; Orientation: Straight; Package Quantity: 1400; Packaging: Tape and Reel; Radiation Hardening: No; Reach SVHC Compliant: Unknown; RoHS Compliant: Yes; Series: B3AL; Termination: SMD/SMT; Throw Configuration: SPST; Voltage Rating (DC): 16V; Weight: 0.18g; Library Name: SUBC
SW2 = CMP-08625-000001-1: SWITCH REED SPST-NO 350MA 140V; Manufacturer: Littelfuse; Standoff Height: 0mm; Automotive: No; Category: Switch; Component Kind: Standard; ComponentLink2Description: Datasheet; ComponentLink2URL: https://www.littelfuse.com/~/media/electronics/datasheets/reed_switches/littelfuse_reed_switches_mdsm_4_datasheet.pdf.pdf; Device Class L1: Electromechanical; Device Class L2: Switches; Device Class L3: unset; Digikey Description: SWITCH REED SPST-NO 350MA 140V; Height: 2.84mm; Lead Free: Yes; Library Name: Altium Content Vault; Manufacturer Part Number: MDSM-4R-12-18; Mouser Description: Magnetic / Reed Switches MDSM-4R 5277 12-18; Octopart: https://octopart.com/mdsm-4r-12-18-littelfuse-55632078; Package: MDSM-4; RoHS: TRUE; Temperature: -40°C to +125°C; Temperature Range High: +125°C; Temperature Range Low: -40°C
U1 = TPS25810RVCR: TPS25810RVCR IC INTERFACE SPECIALIZED 20WQFN; Component Kind: Standard; Library Name: TPS25810RVCR.SchLib; Supplier 1: Mouser; Supplier Part Number 1: 595-TPS25810RVCR
U2 = CMP-069-000000-1: Bluetooth Development Tools (802.15.1) nRF52833 Eval Board, A Nordic nRF52840 DK is recommended for programming this evaluation board; MF: Fanstel Corp.; Availability: In Stock; Check_prices: https://www.snapeda.com/parts/BC833M/Fanstel+Corp./view-part/?ref=eda; Component Kind: Standard; Component Type: Standard; Design Item ID: BC833M; MP: BC833M; Package: None; Pin Count: 28; Price: None; Purchase-URL: https://pricing.snapeda.com/search/part/BC833M/?ref=eda; SnapEDA_Link: https://www.snapeda.com/parts/BC833M/Fanstel+Corp./view-part/?ref=snap; Source: BC833M.SchLib; Library Name: SUBC
U3 = CMP-0272-01072-4: IC OPAMP ZERO-DRIFT 1CIRC SC70-5; Bandwidth: 350kHz; Common Mode Rejection Ratio: 106dB; Input Bias Current: 200pA; Input Offset Voltage (Vos): 10uV; Max Dual Supply Voltage: 2.75V; Max Supply Voltage: 5.5V; Min Dual Supply Voltage: 900mV; Min Supply Voltage: 1.8V; Nominal Supply Current: 25uA; Number of Amplifiers: 1; Operating Supply Current: 17uA; Output Current: 5mA; Power Supply Rejection Ratio (PSRR): 120dB; Slew Rate: 0.16V/µs; Voltage Gain: 130dB; Case/Package: SC; Component Kind: Standard; Contact Plating: Gold; Gain Bandwidth Product: 350kHz; Lead Free: Lead Free; Library Name: Altium Content Vault; Max I/O Voltage: 10uV; Max Operating Temperature: 125°C; Min Operating Temperature: -40°C; Number of Channels: 1; Number of Circuits: 1; Number of Elements: 1; Number of Pins: 5; Operating Supply Voltage: 2.75V; Output Current per Channel: 5mA; Package Quantity: 1; Packaging: Tape and Reel; Radiation Hardening: No; REACH SVHC: No SVHC; Unity Gain Bandwidth Product: 350kHz
U4 = CMP-04917-000300-1: IC REG LINEAR 3.3V 300MA SOT23-5; Component Kind: Standard; Library Name: Altium Content Vault; RoHS Compliant: Yes
U5 = CMP-094-000000-3: I2C, 1A single cell linear battery charger, 18V input, powerpath, ship mode and battery tracking 8-DSBGA -40 to 85; MF: {'manufacturer': u'Texas Instruments'}; MP: BQ25180YBGR; Availability: Unavailable; Component Kind: Standard; Component Type: Standard; Design Item ID: BQ25188YBGR; Package: None; Pin Count: 8; Price: None; Source: BQ25188YBGR.SchLib; Library Name: SUBC
U6 = CMP-04937-000024-1: PRECISION ISOLATED CURRENT SENSO; Component Kind: Standard; Library Name: Altium Content Vault
U7 = CMP-04918-000107-1: IC REG BOOST ADJ 7A 11VQFN; Manufacturer: Texas Instruments; Max Duty Cycle: 90%; Max Junction Temp: +125°C; Max Supply Voltage: 12V; Min Supply Voltage: 2.7V; Nominal Supply Current: 10uA; Number Of Outputs: 1; Output Current: 8.1-10A; Output Type: AdjustableProgrammable; Output Voltage: 4.5-12.6V; Standoff Height: 0mm; Switching Frequency: 200-2200kHz; Automotive: No; Category: IC; Component Kind: Standard; ComponentLink2Description: Datasheet; ComponentLink2URL: http://www.ti.com/lit/ds/symlink/tps61089.pdf; Device Class L1: Integrated Circuits (ICs); Device Class L2: Power Management ICs; Device Class L3: Voltage Regulators - Switching; Digikey Description: IC REG BOOST ADJ 7A 11VQFN; Height: 1mm; Lead Free: Yes; Library Name: Altium Content Vault; Manufacturer Part Number: TPS61089RNRR; Octopart: https://octopart.com/tps61089rnrr-texas+instruments-68059594; Package: RNR0011A; RoHS: TRUE; Switching Topology: Boost; Temperature: -40°C to +85°C; Temperature Range High: +85°C; Temperature Range Low: -40°C
